# Altium schematic sheet Open_door_sensor_scheme.SchDoc
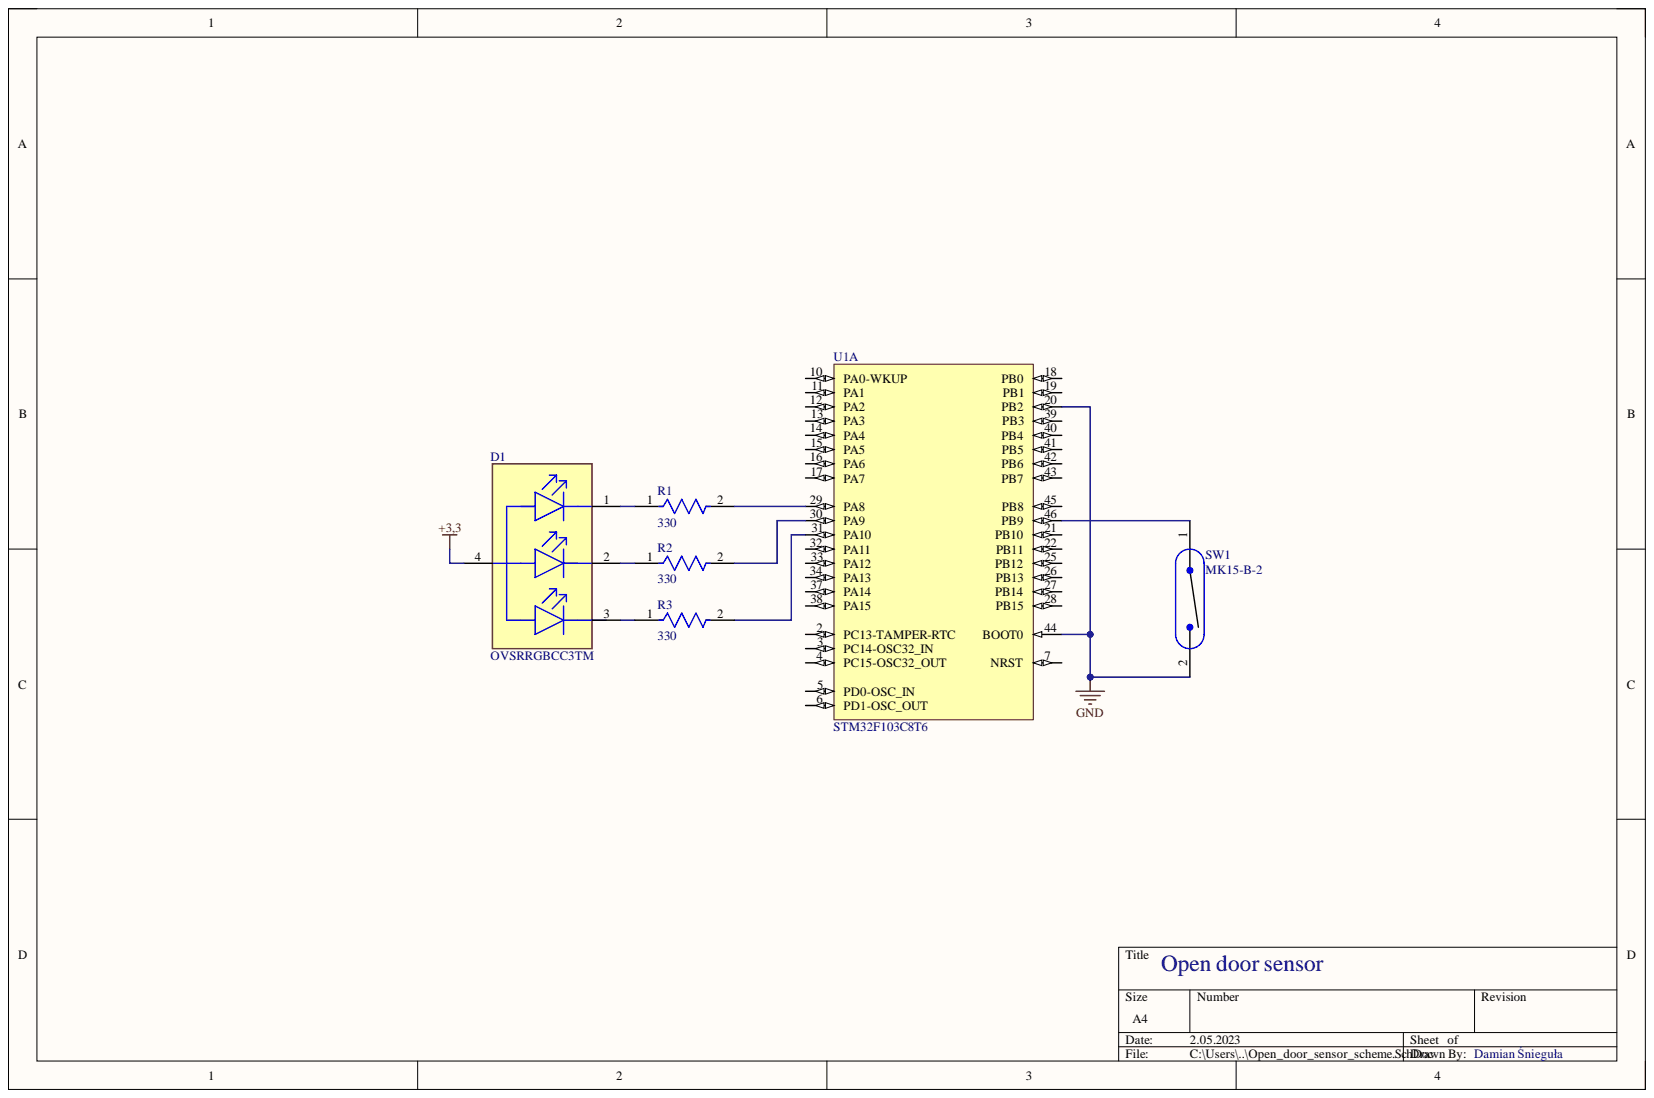
[diagram p0: Open_door_sensor_scheme.SchDoc]

## Parts
D1  OVSRRGBCC3TM  [FP-OVSRRGBCC3TM-MFG]
R1  330  [FP-CRCW0603-e3-MFG]
R2  330  [FP-CRCW0603-e3-MFG]
R3  330  [FP-CRCW0603-e3-MFG]
SW1  MK15-B-2  [STAN-MK15-B-2_V]
U1  STM32F103C8T6  [STM-LQFP48_L]  (part A)

## Nets
+3,3: D1.4
GND: SW1.2 U1.20(PB2) U1.44(BOOT0)
NetD1_1: D1.1 R1.1
NetD1_2: D1.2 R2.1
NetD1_3: D1.3 R3.1
NetR1_2: R1.2 U1.29(PA8)
NetR2_2: R2.2 U1.30(PA9)
NetR3_2: R3.2 U1.31(PA10)
NetSW1_1: SW1.1 U1.46(PB9)

Not connected: U1 2(PC13-TAMPER-RTC) 3(PC14-OSC32_IN) 4(PC15-OSC32_OUT) 5(PD0-OSC_IN) 6(PD1-OSC_OUT) 7(NRST) 10(PA0-WKUP) 11(PA1) 12(PA2) 13(PA3) 14(PA4) 15(PA5) 16(PA6) 17(PA7) 18(PB0) 19(PB1) 21(PB10) 22(PB11) 25(PB12) 26(PB13) 27(PB14) 28(PB15) 32(PA11) 33(PA12) 34(PA13) 37(PA14) 38(PA15) 39(PB3) 40(PB4) 41(PB5) 42(PB6) 43(PB7) 45(PB8)

## Library data of the parts
D1 = CMP-70659-000001-1: LED RGB DIFFUSED 1204 SMD; Color: Blue; Dominant Wavelength: 475nm; Forward Voltage: 3.3V; Lens Color: White; Lens Style: Dome; Luminous Intensity: 200mcd; Test Current: 20mA; Viewing Angle: 150°; Case/Package: SMD/SMT; Component Kind: Standard; Height: 1.5mm; Lead Free: Lead Free; Length: 3.2mm; Lens Transparency: Diffused; Library Name: Altium Content Vault; Mount: Surface Mount; Packaging: Cut Tape; REACH SVHC: No SVHC; RoHS Compliant: Yes; Series: -; Width: 1mm
R1-R3 = CMP-1012-00454-3: RES Thick Film, 330Ω, 1%, 0.1W, 100ppm/°C, 0603; Max Power Dissipation: 100mW; Resistance: 330R; Voltage Rating: 75V; Case Code (Imperial): 0603; Case Code (Metric): 1608; Case/Package: 0603; Component Kind: Standard; Composition: Thick Film; Contact Plating: Tin; Depth: 0.85mm; Features: Automotive AEC-Q200; Height: 0.45mm; Lead Free: Lead Free; Length: 1.55mm; Library Name: Altium Content Vault; Max Operating Temperature: 155°C; Military Standard: Not; Min Operating Temperature: -55°C; Mount: Surface Mount; Number of Terminations: 2; Package Quantity: 10; Packaging: Tape and Reel; Power Rating: 100mW; Radiation Hardening: No; Temperature Coefficient: 100ppm/°C; Termination: SMD/SMT; Tolerance: 1%; Voltage Rating (DC): 75V; Weight: 7.1E-05oz; Width: 0.033inch
SW1 = CMP-2000-06712-1: Reed Sensor, SPST-NO, 10 W, 180 V, -40 to 130 degC, 2-Pin SMD, RoHS, Tape and Reel; Manufacturer: Standex Meder; Component Kind: Standard; Datasheet URL: https://standexelectronics.com/wp-content/uploads/datasheet_reed_sensor_MK15.pdf; Datasheet Version: Rev. 01, 01/2015; Library Name: Altium Content Vault; Manufacturer URL: https://standexelectronics.com; Mounting Technology: SurfaceMount; Package Description: 2-Pin Surface Mount Device, Body 16 x 2.5 mm; Package Reference: MK15-B-2; Package URL: http://www.farnell.com/datasheets/34737.pdf
U1 = CMP-0237-00215-3: ARM Cortex-M3 32-bit MCU, 64 KB Flash, 20 KB Internal RAM, 37 I/Os, 48-pin LQFP, -40 to 85 degC, Tray; A/D Converter: 2x12-bit (10 Channel); FLASH Size (Prog) (kB): 64; I/Os (High Current): 37; Internal RAM Size (kB): 20; Operating Frequency(F) (Processor speed) (MHz): 72; Supply Voltage(Vcc) min (V): 2; Component Kind: Standard; ComponentLink1Description: Manufacturer URL; ComponentLink1URL: http://www.st.com/web/en/home.html; ComponentLink2Description: Datasheet; ComponentLink2URL: http://www.st.com/st-web-ui/static/active/en/resource/technical/document/datasheet/CD00161566.pdf; ComponentLink3Description: Package Specification; ComponentLink3URL: http://www.st.com/st-web-ui/static/active/en/resource/technical/document/datasheet/DM00058181.pdf; Core: ARM Cortex-M3; DatasheetVersion: Rev. 16; Library Name: Altium Content Vault; Mounting Technology: Surface Mount; PackageDescription: 48-Pin Low Profile Quad Flat Package, 7 x 7 mm Body, 0.5 mm Pitch; PackageReference: LQFP48; PackageVersion: Rev. 6, 06/2013; Packing: Tray; PartNumber: STM32F103C8T6
